# Altium schematic sheet FPGA.SchDoc(FPGA)
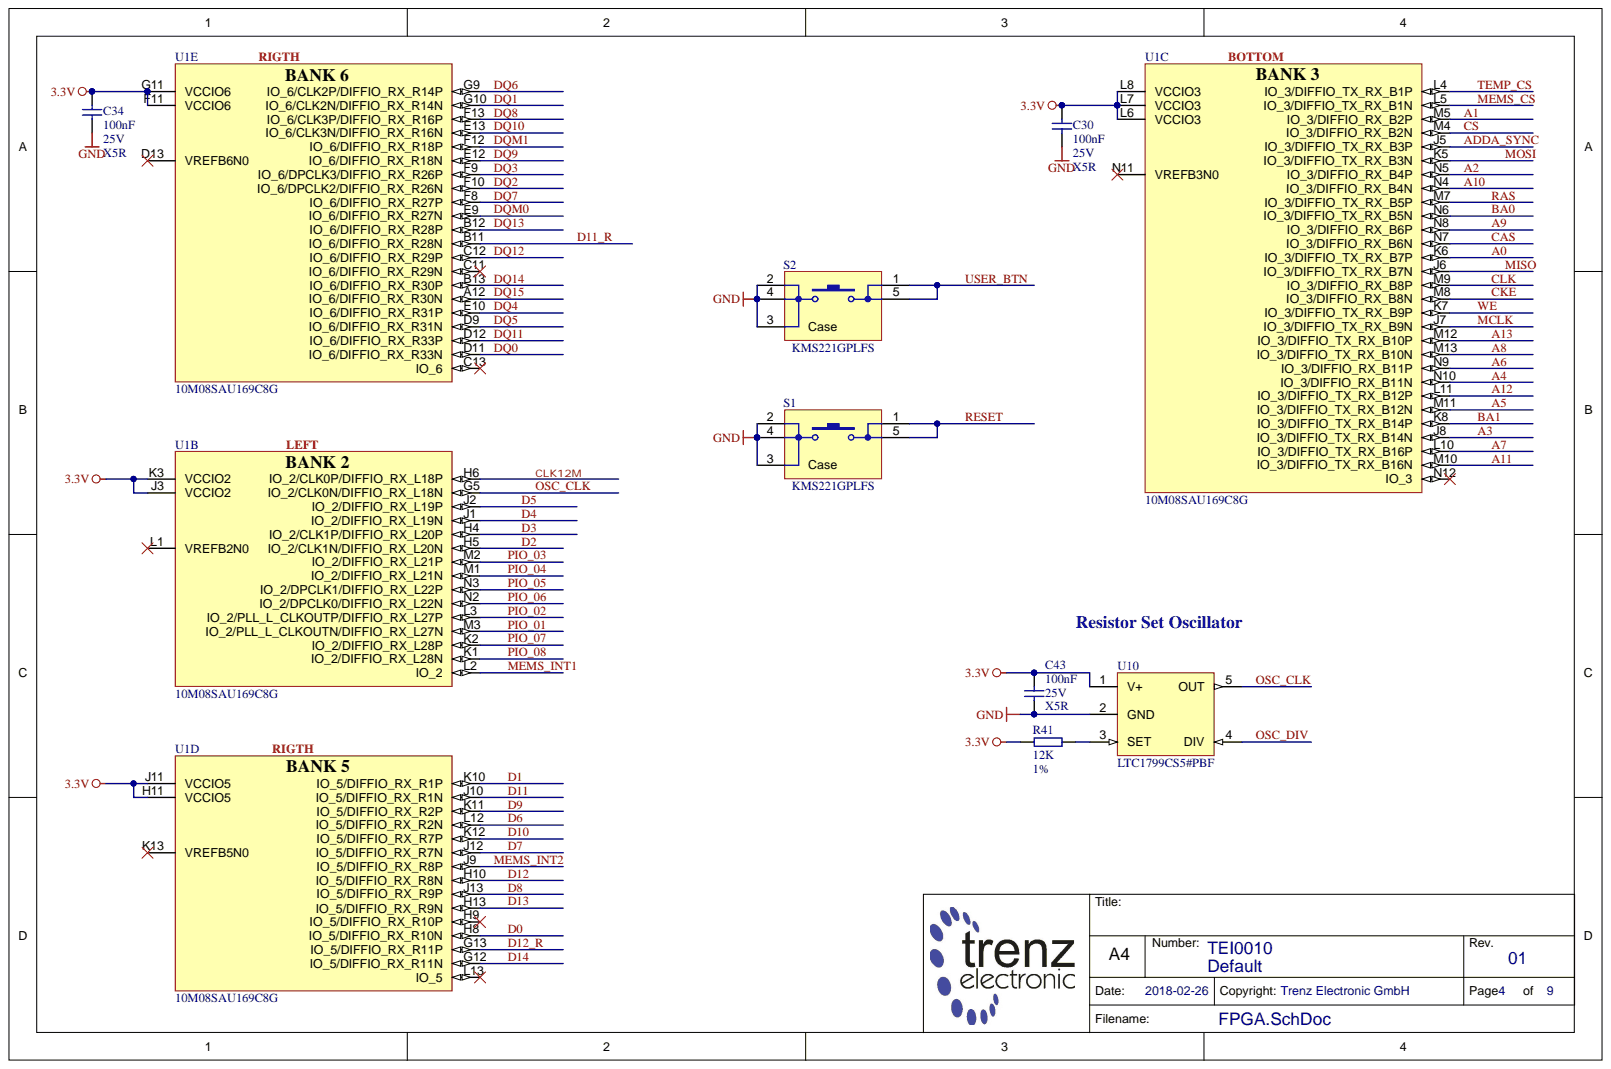
[diagram p0: FPGA.SchDoc]

## Parts
C30  100nF  Technology: X5R  Voltage: 25V  [CAPC1005X55N]
C34  100nF  Technology: X5R  Voltage: 25V  [CAPC1005X55N]
C43  100nF  Technology: X5R  Voltage: 25V  [CAPC1005X55N]
R41  12K  Tolerance: 1%  [RESC1005X40N]
S1  KMS221GPLFS  [KMS2xxGPLFS]
S2  KMS221GPLFS  [KMS2xxGPLFS]
U1  10M08SAU169C8G  [BGA169C80P13X13_11X11X15]  (parts B,C,D,E)
U10  LTC1799CS5#PBF  [SOT95P280X100-5N]

## Nets
3.3V: C30.1 C34.1 C43.2 R41.2 U1.F11(VCCIO6) U1.G11(VCCIO6) U1.H11(VCCIO5) U1.J3(VCCIO2) U1.J11(VCCIO5) U1.K3(VCCIO2) U1.L6(VCCIO3) U1.L7(VCCIO3) U1.L8(VCCIO3) U10.1(V+)
GND: C30.2 C34.2 C43.1 S1.2 S1.3(Case) S1.4 S2.2 S2.3(Case) S2.4 U10.2(GND)
A0: U1.K6(IO_3/DIFFIO_TX_RX_B7P)
A1: U1.M5(IO_3/DIFFIO_RX_B2P)
A2: U1.N5(IO_3/DIFFIO_RX_B4P)
A3: U1.J8(IO_3/DIFFIO_TX_RX_B14N)
A4: U1.N10(IO_3/DIFFIO_RX_B11N)
A5: U1.M11(IO_3/DIFFIO_TX_RX_B12N)
A6: U1.N9(IO_3/DIFFIO_RX_B11P)
A7: U1.L10(IO_3/DIFFIO_TX_RX_B16P)
A8: U1.M13(IO_3/DIFFIO_TX_RX_B10N)
A9: U1.N8(IO_3/DIFFIO_RX_B6P)
A10: U1.N4(IO_3/DIFFIO_RX_B4N)
A11: U1.M10(IO_3/DIFFIO_TX_RX_B16N)
A12: U1.L11(IO_3/DIFFIO_TX_RX_B12P)
A13: U1.M12(IO_3/DIFFIO_TX_RX_B10P)
ADDA_SYNC: U1.J5(IO_3/DIFFIO_TX_RX_B3P)
BA0: U1.N6(IO_3/DIFFIO_TX_RX_B5N)
BA1: U1.K8(IO_3/DIFFIO_TX_RX_B14P)
CAS: U1.N7(IO_3/DIFFIO_RX_B6N)
CKE: U1.M8(IO_3/DIFFIO_RX_B8N)
CLK: U1.M9(IO_3/DIFFIO_RX_B8P)
CLK12M: U1.H6(IO_2/CLK0P/DIFFIO_RX_L18P)
CS: U1.M4(IO_3/DIFFIO_RX_B2N)
D0: U1.H8(IO_5/DIFFIO_RX_R10N)
D1: U1.K10(IO_5/DIFFIO_RX_R1P)
D2: U1.H5(IO_2/CLK1N/DIFFIO_RX_L20N)
D3: U1.H4(IO_2/CLK1P/DIFFIO_RX_L20P)
D4: U1.J1(IO_2/DIFFIO_RX_L19N)
D5: U1.J2(IO_2/DIFFIO_RX_L19P)
D6: U1.L12(IO_5/DIFFIO_RX_R2N)
D7: U1.J12(IO_5/DIFFIO_RX_R7N)
D8: U1.J13(IO_5/DIFFIO_RX_R9P)
D9: U1.K11(IO_5/DIFFIO_RX_R2P)
D10: U1.K12(IO_5/DIFFIO_RX_R7P)
D11: U1.J10(IO_5/DIFFIO_RX_R1N)
D11_R: U1.B11(IO_6/DIFFIO_RX_R28N)
D12: U1.H10(IO_5/DIFFIO_RX_R8N)
D12_R: U1.G13(IO_5/DIFFIO_RX_R11P)
D13: U1.H13(IO_5/DIFFIO_RX_R9N)
D14: U1.G12(IO_5/DIFFIO_RX_R11N)
DQ0: U1.D11(IO_6/DIFFIO_RX_R33N)
DQ1: U1.G10(IO_6/CLK2N/DIFFIO_RX_R14N)
DQ2: U1.F10(IO_6/DPCLK2/DIFFIO_RX_R26N)
DQ3: U1.F9(IO_6/DPCLK3/DIFFIO_RX_R26P)
DQ4: U1.E10(IO_6/DIFFIO_RX_R31P)
DQ5: U1.D9(IO_6/DIFFIO_RX_R31N)
DQ6: U1.G9(IO_6/CLK2P/DIFFIO_RX_R14P)
DQ7: U1.F8(IO_6/DIFFIO_RX_R27P)
DQ8: U1.F13(IO_6/CLK3P/DIFFIO_RX_R16P)
DQ9: U1.E12(IO_6/DIFFIO_RX_R18N)
DQ10: U1.E13(IO_6/CLK3N/DIFFIO_RX_R16N)
DQ11: U1.D12(IO_6/DIFFIO_RX_R33P)
DQ12: U1.C12(IO_6/DIFFIO_RX_R29P)
DQ13: U1.B12(IO_6/DIFFIO_RX_R28P)
DQ14: U1.B13(IO_6/DIFFIO_RX_R30P)
DQ15: U1.A12(IO_6/DIFFIO_RX_R30N)
DQM0: U1.E9(IO_6/DIFFIO_RX_R27N)
DQM1: U1.F12(IO_6/DIFFIO_RX_R18P)
MCLK: U1.J7(IO_3/DIFFIO_TX_RX_B9N)
MEMS_CS: U1.L5(IO_3/DIFFIO_TX_RX_B1N)
MEMS_INT1: U1.L2(IO_2)
MEMS_INT2: U1.J9(IO_5/DIFFIO_RX_R8P)
MISO: U1.J6(IO_3/DIFFIO_TX_RX_B7N)
MOSI: U1.K5(IO_3/DIFFIO_TX_RX_B3N)
OSC_CLK: U1.G5(IO_2/CLK0N/DIFFIO_RX_L18N) U10.5(OUT)
OSC_DIV: U10.4(DIV)
PIO_01: U1.M3(IO_2/PLL_L_CLKOUTN/DIFFIO_RX_L27N)
PIO_02: U1.L3(IO_2/PLL_L_CLKOUTP/DIFFIO_RX_L27P)
PIO_03: U1.M2(IO_2/DIFFIO_RX_L21P)
PIO_04: U1.M1(IO_2/DIFFIO_RX_L21N)
PIO_05: U1.N3(IO_2/DPCLK1/DIFFIO_RX_L22P)
PIO_06: U1.N2(IO_2/DPCLK0/DIFFIO_RX_L22N)
PIO_07: U1.K2(IO_2/DIFFIO_RX_L28P)
PIO_08: U1.K1(IO_2/DIFFIO_RX_L28N)
RAS: U1.M7(IO_3/DIFFIO_TX_RX_B5P)
RESET: S1.1 S1.5
TEMP_CS: U1.L4(IO_3/DIFFIO_TX_RX_B1P)
USER_BTN: S2.1 S2.5
WE: U1.K7(IO_3/DIFFIO_TX_RX_B9P)
NetR41_1: R41.1 U10.3(SET)

Not connected: U1 C11(IO_6/DIFFIO_RX_R29N) C13(IO_6) D13(VREFB6N0) H9(IO_5/DIFFIO_RX_R10P) K13(VREFB5N0) L1(VREFB2N0) L13(IO_5) N11(VREFB3N0) N12(IO_3)

## Library data of the parts
C30 C34 C43 = 22472: KON 100nF 25V X5R SMD ±10%; Database Table Name: C_1005_0402; Mixed: SMT; Preferred: Yes; Value: 100n; AN: 22472; Case: 1005 (0402); Component Kind: Standard; Library Name: TE.DbLib
R41 = 22598: WID SMD 12K 1% 0,063W; Database Table Name: R_1005_0402; Mixed: SMT; Preferred: Yes; AN: 22598; Case: 1005 (0402); Component Kind: Standard; Library Name: TE.DbLib; Technology: TK100; Value: 12K
S1 S2 = 28549: Database Table Name: PB_SW; Mixed: SMT; AN: 28549; Component Kind: Standard; Description: KMS221GPLFS; H-ART: KMS221GPLFS; Library Name: TE.DbLib; HelpURL: B:\AD\adlib\datasheet\KMS
U1 = 27930: Database Table Name: PROG_LOGIC; Mixed: SMT; AN: 27930; Case: LFBGA169; Component Kind: Standard; Description: 10M08SAU169C8G; H-ART: 10M08SAU169C8G; Library Name: TE.DbLib; HelpURL: b:\AD\adlib\datasheet\ALTERA_MAX10\
U10 = 28909: Database Table Name: CLK; Mixed: SMT; AN: 28909; Case: SOT23-5; Component Kind: Standard; Description: LTC1799CS5#PBF; H-ART: LTC1799CS5#PBF; Library Name: TE.DbLib; HelpURL: b:\AD\adlib\datasheet\LTC1799\
